# Altium schematic sheet Sheet2.SchDoc
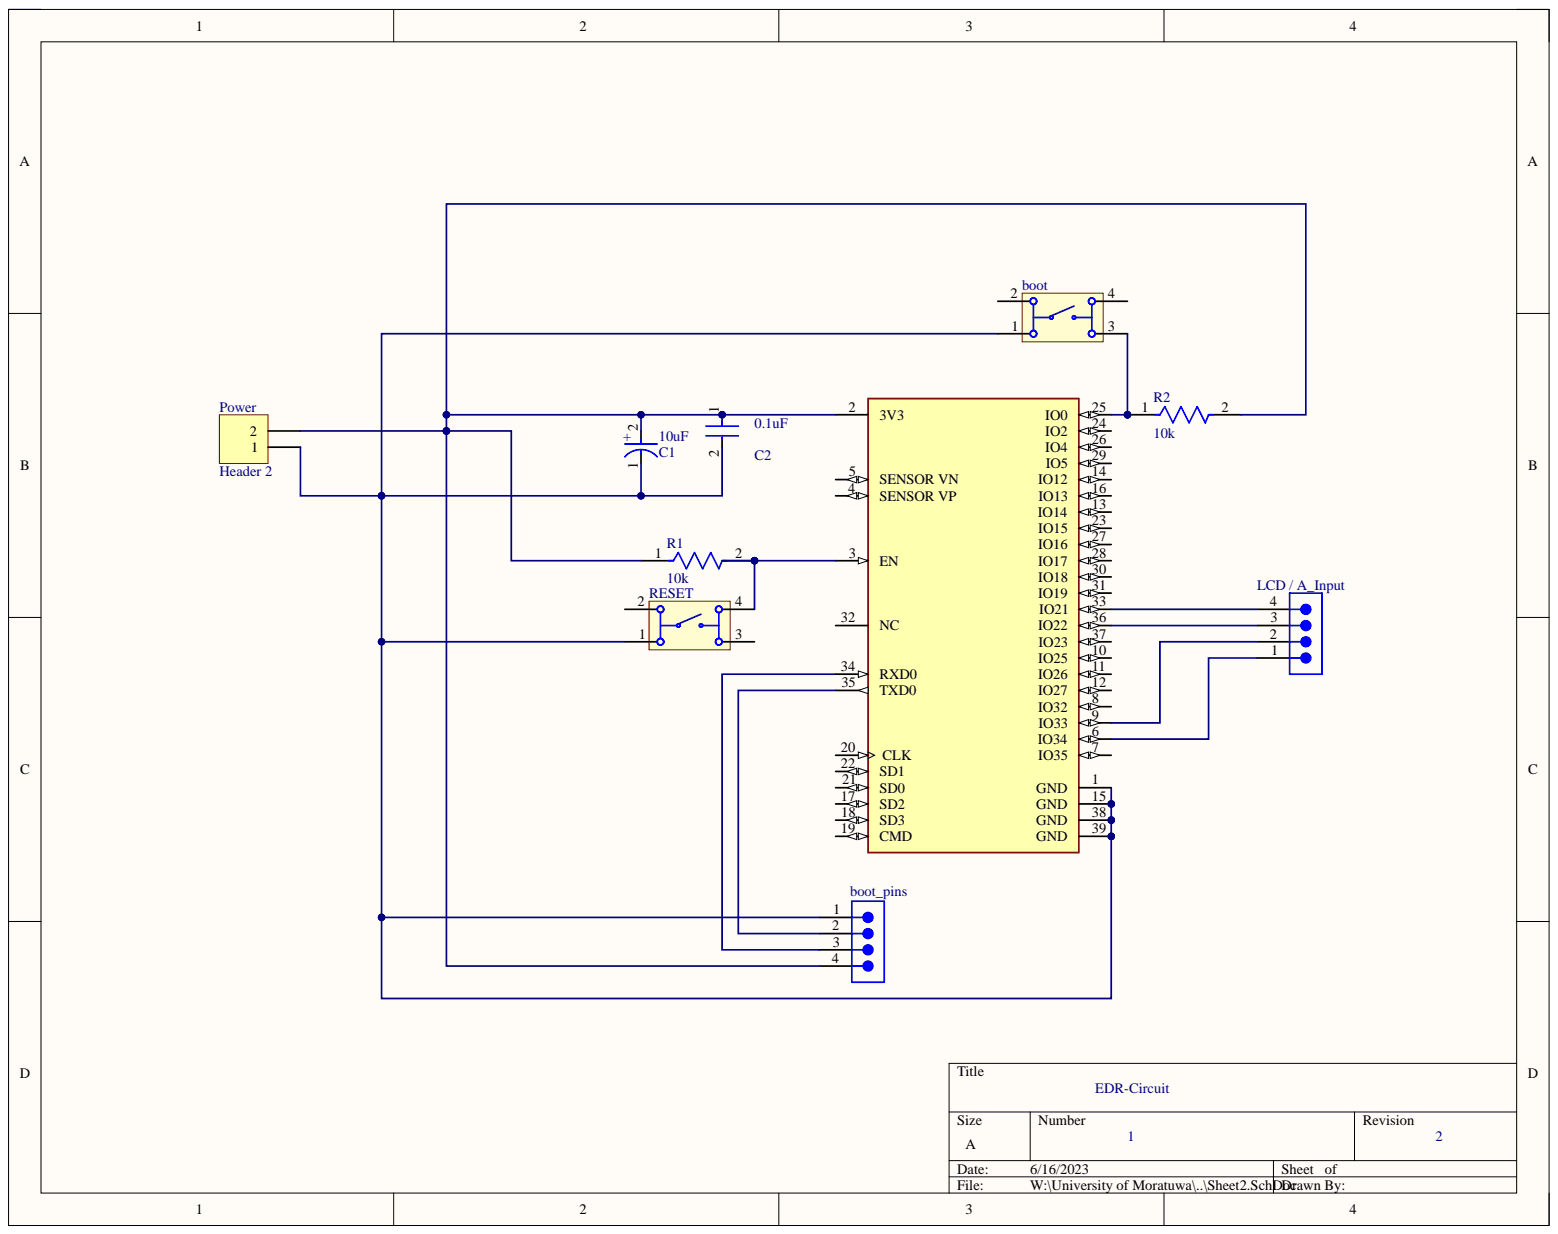
[diagram p0: Sheet2.SchDoc]

## Parts
0.1uF  C2  [FP-RCE0DB-MFG]
10uF  C1  [FP-RAD-TH-D_10_0_5-L_16_1-MFG]
boot  430471025826  [430471025826]  (value not drawn)
boot_pins  TSW-104-08-L-S  [SMTC-TSW-104-08-X-S]  (value not drawn)
esp32  ESP32-WROOM-32D  [FP-ESP32-WROOM-32D-MFG]  (value not drawn)
LCD_/_A_Input  TSW-104-08-L-S  [SMTC-TSW-104-08-X-S]  (value not drawn)
Power  Header 2  [HDR1X2]
R1  10k  [FP-MBB0207-MFG]
R2  10k  [FP-MBB0207-MFG]
RESET  430471025826  [430471025826]  (value not drawn)

## Nets
Net0.1uF_1: 0.1uF.1 10uF.2 boot_pins.4 esp32.2(3V3) Power.2 R1.1 R2.2
Net0.1uF_2: 0.1uF.2 10uF.1 boot.1 boot_pins.1 esp32.1(GND) esp32.15(GND) esp32.38(GND) esp32.39(GND) Power.1 RESET.1
Netboot_3: boot.3 esp32.25(IO0) R2.1
Netboot_pins_2: boot_pins.2 esp32.35(TXD0)
Netboot_pins_3: boot_pins.3 esp32.34(RXD0)
Netesp32_3: esp32.3(EN) R1.2 RESET.4
Netesp32_6: esp32.6(IO34) LCD_/_A_Input.1
Netesp32_9: esp32.9(IO33) LCD_/_A_Input.2
Netesp32_33: esp32.33(IO21) LCD_/_A_Input.4
Netesp32_36: esp32.36(IO22) LCD_/_A_Input.3

Not connected: boot 2 4; esp32 4(SENSOR_VP) 5(SENSOR_VN) 7(IO35) 8(IO32) 10(IO25) 11(IO26) 12(IO27) 13(IO14) 14(IO12) 16(IO13) 17(SD2) 18(SD3) 19(CMD) 20(CLK) 21(SD0) 22(SD1) 23(IO15) 24(IO2) 26(IO4) 27(IO16) 28(IO17) 29(IO5) 30(IO18) 31(IO19) 32(NC) 37(IO23); RESET 2 3

## Library data of the parts
0.1uF = CMP-06035-048089-1: Cap Ceramic 100nF 50V X7R ±10% Radial 2.5mm +125°C Ammo Pack; Lead Diameter: 0.5mm; Lead/Base Style: Straight; Capacitance: 100nF; Case/Package: Radial; Component Kind: Standard; Dielectric: X7R; Height: 3.5mm; Height - Seated (Max): 0.138inch; Lead Free: Lead Free; Lead Pitch: 0.098inch; Length: 0.142inch; Library Name: Altium Content Vault; Max Operating Temperature: 125°C; Min Operating Temperature: -55°C; Mount: Through Hole; Package Quantity: 2000; Packaging: Tape and Reel; Ratings: AEC-Q200; Resistor Type: Ceramic; Termination: Radial; Tolerance: 10%; Voltage Rating: 50V; Voltage Rating (DC): 50V; Width: 0.098inch
10uF = CMP-05427-000979-1: Aluminum Electrolytic Capacitors (Radial Lead Type) AEC-Q200 Qualified 1000uF ±20% 10V; Capacitance: 1mF; Dielectric Material: Aluminium; ESR (Equivalent Series Resistance): 68mR; Lead Diameter: 0.6mm; Leakage Current: 3uA; Life (Hours): 3000Hour; Ripple Current: 1.05A; Case/Package: Radial; Component Kind: Standard; Construction: RADIAL; Contact Plating: Tin; Diameter: 10mm; Height: 16mm; Height - Seated (Max): 0.63inch; Impedance: 68mR; Lead Free: Lead Free; Lead Pitch: 5mm; Length: 16mm; Library Name: Altium Content Vault; Max Operating Temperature: 105°C; Min Operating Temperature: -55°C; Mount: Through Hole; Package Quantity: 200; Packaging: Bulk; Radiation Hardening: No; Ratings: AEC-Q200; REACH SVHC: No SVHC; RoHS Compliant: Yes; Series: FC; Size: 16mm; Termination: Radial; Tolerance: 20%; Voltage: 10V; Voltage Rating: 10V; Voltage Rating (DC): 10V
boot RESET = CMP-1452-00022-1: WS-TASV SMD Tact Switch 6X6 mm; Manufacturer: Wuerth Elektronik; NoPins: 04; Component Kind: Standard; ComponentLink1Description: Manufacturer URL; ComponentLink1URL: http://www.we-online.com; ComponentLink2Description: Datasheet; ComponentLink2URL: http://katalog.we-online.de/em/datasheet/4304x1.pdf; Library Name: Altium Content Vault; PackageReference: 430471025826; PartNumber: 430471025826
boot_pins LCD_/_A_Input = CMP-1024-00358-1: 0.025" SQ Post Header, Through-hole, Vertical, -55 to 125 degC, 2.54 mm Pitch, 4-Pin, Male, RoHS; Manufacturer: Samtec; Pitch: 2.54 mm; Component Kind: Standard; ComponentLink1Description: Manufacturer URL; ComponentLink1URL: http://www.samtec.com; ComponentLink2Description: Datasheet; ComponentLink2URL: http://www.samtec.com/documents/webfiles/pdf/TSW_TH.PDF; ComponentLink3Description: Package Specification; ComponentLink3URL: http://www.samtec.com/documents/webfiles/cpdf/TSW-XXX-XX-XXX-X-XX-XXX-MKT.pdf; DatasheetVersion: F-214; Library Name: Altium Content Vault; Mounting Technology: Through-hole; Orientation: Vertical; PackageDescription: .025[0.64] SQ. Post Terminal Strip Assembly; PackageReference: TSW-104-08-X-S; PackageVersion: DM, 8/1988; PartNumber: TSW-104-08-L-S; RoHS: True; Temperature Range: -55 to 125 degC
esp32 = CMP-194065-000003-1: WIFI MODULE 32MBITS SPI FLASH; Manufacturer: Espressif Systems; Bandwidth: 72MHz; Data Rate: 150Mbits/s; Interface: I2C,SPI,UART,Ethernet,I2S,Other; Max Frequency: 2484MHz; Max Supply Voltage: 3.6V; Min Supply Voltage: 3V; Nominal Supply Current: 500mA; Number Of Adc Channels: 16; Number Of Gpio: 32; Sensitivity: -98dBm; Automotive: No; Category: UNK; Component Kind: Standard; ComponentLink2Description: Datasheet; ComponentLink2URL: https://www.espressif.com/sites/default/files/documentation/esp32-wroom-32d_esp32-wroom-32u_datasheet_en.pdf; Device Class L1: Integrated Circuits (ICs); Device Class L2: RF Semiconductors and Devices; Device Class L3: Transceivers; Digikey Description: WIFI MODULE 32MBITS SPI FLASH; Height: 3.2mm; Lead Free: Yes; Library Name: Altium Content Vault; Manufacturer Part Number: ESP32-WROOM-32D; Mouser Description: Wi-Fi/BT BLE Module ESP32-D0WD, SPI Flash, UART, P; Octopart: https://octopart.com/esp32-wroom-32d-espressif+systems-91040050; Package: ESP32-WROOM-32D; RoHS: TRUE; Temperature: -40°C to +85°C; Temperature Range High: +85°C; Temperature Range Low: -40°C
Power = CMP-307-000070-1: Header, 2-Pin; Component Kind: Standard; Component Type: Standard; Design Item ID: Header 2; LatestRevisionDate: 17-Jul-2002; LatestRevisionNote: Re-released for DXP Platform.; Pin Count: 2; Signal Integrity: Connector; Source: Miscellaneous Connectors.SchLib; Library Name: University of Moratuwa; Publisher: Altium Limited
R1 R2 = CMP-02408-000035-1: RES 10K OHM 0.6W 1% AXIAL; Max Power Dissipation: 600mW; Resistance: 10kR; Temperature Coefficient: 50ppm/°C; Voltage Rating: 300V; Case/Package: Conformal; Component Kind: Standard; Construction: Cylindrical; Contact Plating: Tin; Diameter: 2.5mm; Features: Automotive AEC-Q200; Lead Free: Lead Free; Length: 6.5mm; Library Name: Altium Content Vault; Max Operating Temperature: 155°C; Military Standard: Not; Min Operating Temperature: -55°C; Number of Terminations: 2; Packaging: Tape and Reel; Power Rating: 600mW; Radiation Hardening: No; Resistance Tolerance: 1%; Resistor Type: Metal Film; RoHS Compliant: Yes; Series: MBB 0207 - Professional; Technology: THIN FILM; Termination: Axial; Tolerance: 1%; Voltage Rating (DC): 300V
